# Altium schematic sheet HIGHSPEED_v0_SF2-COMM.SchDoc
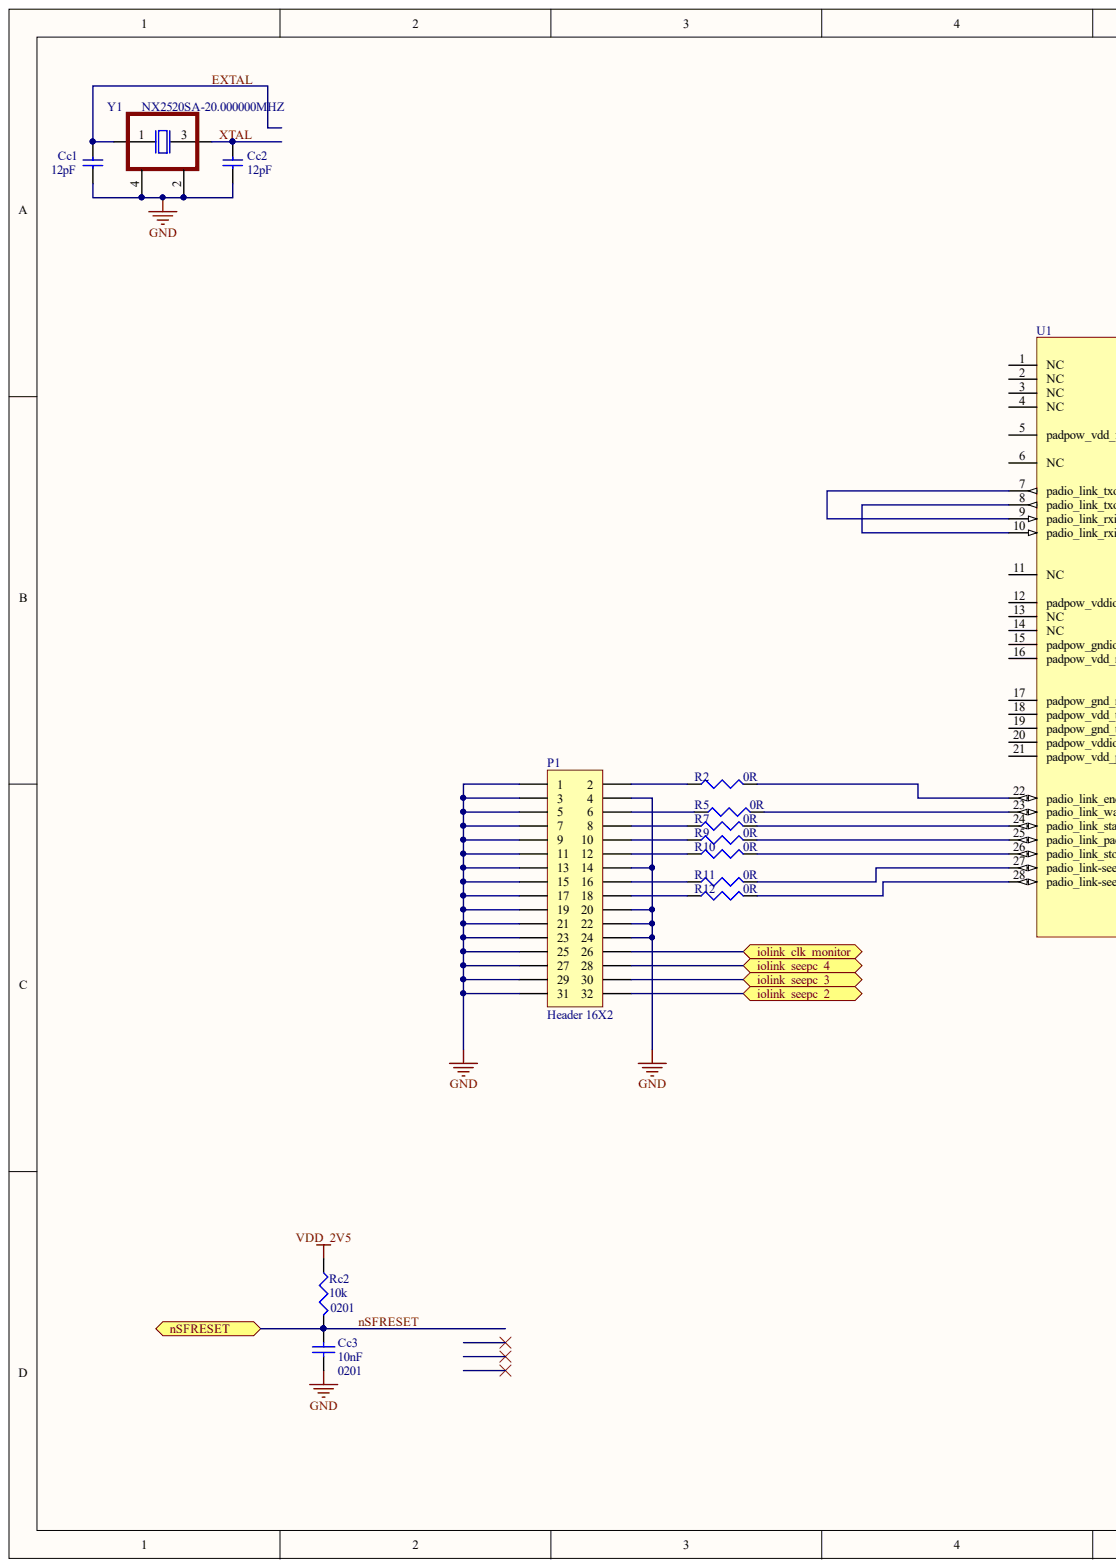
[diagram p0: HIGHSPEED_v0_SF2-COMM.SchDoc, left]
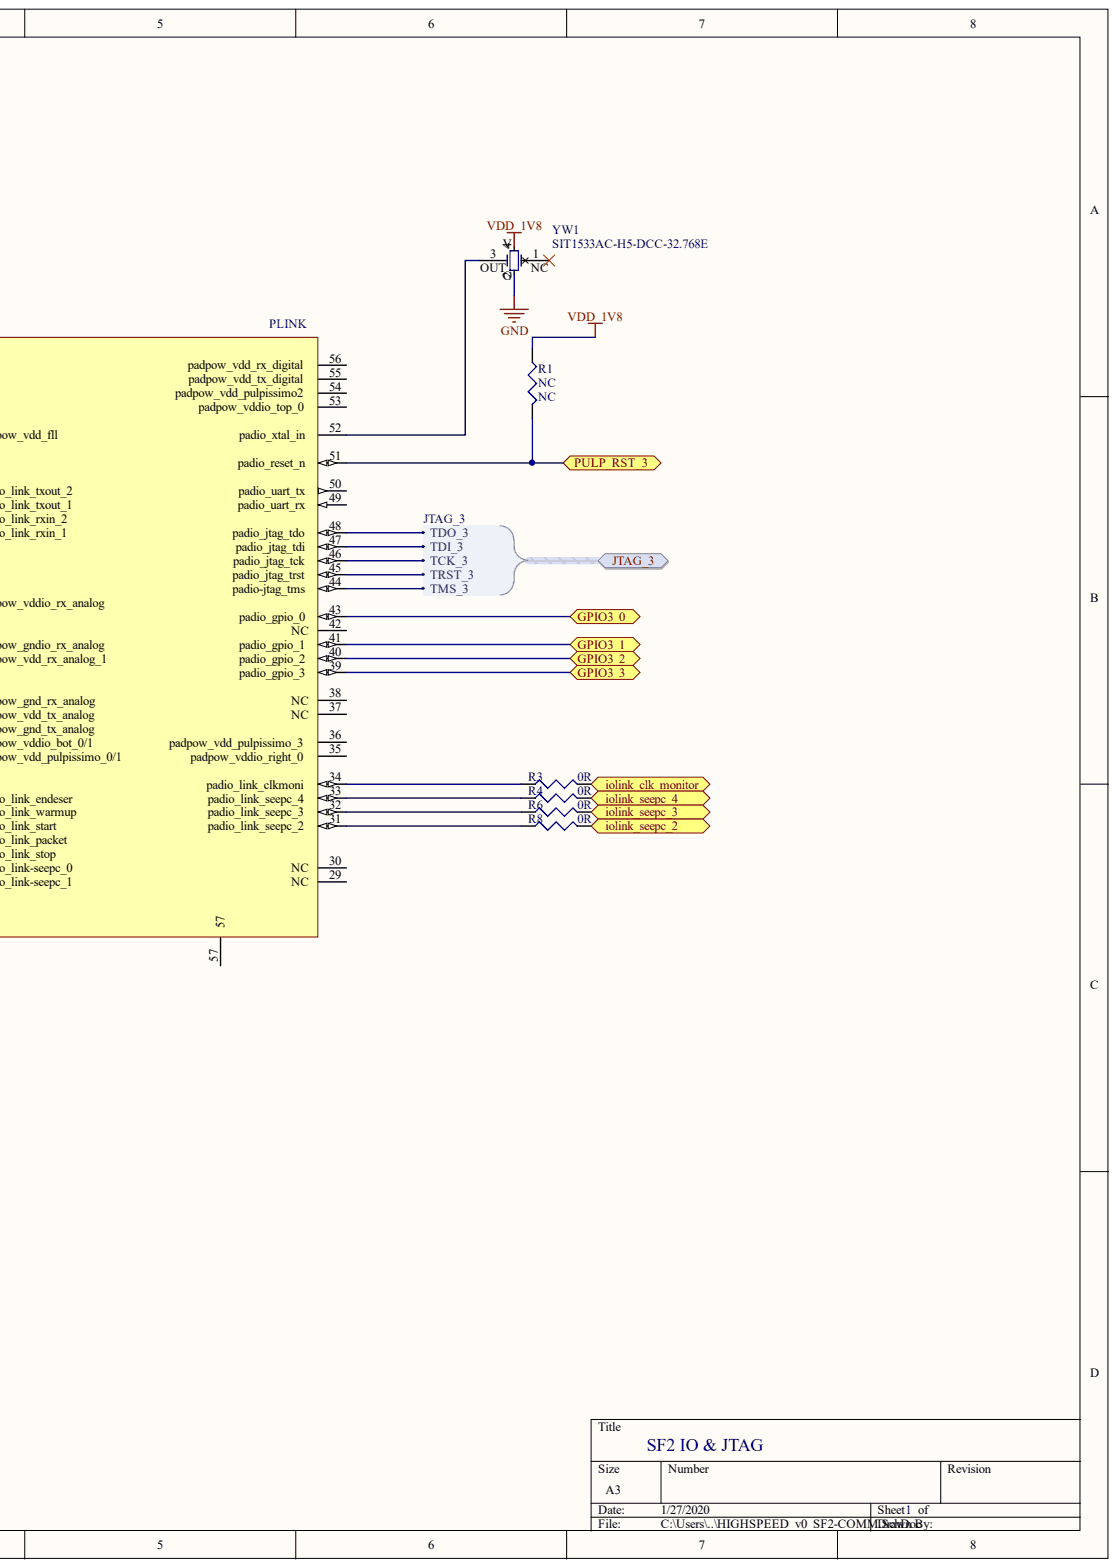
[diagram p1: HIGHSPEED_v0_SF2-COMM.SchDoc, right]

## Parts
Cc1  value 12pF  [c0402-Murata-GR]  (hidden comment: GRM1555C1H120FA01D)
Cc2  value 12pF  [c0402-Murata-GR]  (hidden comment: GRM1555C1H120FA01D)
Cc3  0201  value 10nF  [c0201-Murata-GR]
P1  Header 16X2  [HDR2X16]
R1  NC  [0402]
R2  0R  [0402]
R3  0R  [0402]
R4  0R  [0402]
R5  0R  [0402]
R6  0R  [0402]
R7  0R  [0402]
R8  0R  [0402]
R9  0R  [0402]
R10  0R  [0402]
R11  0R  [0402]
R12  0R  [0402]
Rc2  value 10k  Package: 0201  [0201_p]  (hidden comment: ERJ-1GEF1002C)
U1  PLINK  [QFN56_M]
Y1  NX2520SA-20.000000MHZ  [XOSC_4PIN]
YW1  SIT1533AC-H5-DCC-32.768E  [SiT1533-C]

## Ports
GPIO3_0, GPIO3_1, GPIO3_2, GPIO3_3, IOLINK_CLK_MONITOR, IOLINK_SEEPC_2, IOLINK_SEEPC_3, IOLINK_SEEPC_4, JTAG_3, JTAG_3.TCK_3, JTAG_3.TDI_3, JTAG_3.TDO_3, JTAG_3.TMS_3, JTAG_3.TRST_3, NSFRESET, PULP_RST_3

## Nets
GND: Cc1.1 Cc2.1 Cc3.1 P1.1 P1.3 P1.4 P1.5 P1.7 P1.9 P1.11 P1.13 P1.14 P1.15 P1.17 P1.19 P1.20 P1.21 P1.22 P1.23 P1.24 P1.25 P1.27 P1.29 P1.31 Y1.2 Y1.4 YW1.2
VDD_1V8: R1.2 YW1.4
VDD_2V5: Rc2.1
EXTAL: Cc1.2 Y1.1
GPIO3_0: U1.43(padio_gpio_0) ; port GPIO3_0
GPIO3_1: U1.41(padio_gpio_1) ; port GPIO3_1
GPIO3_2: U1.40(padio_gpio_2) ; port GPIO3_2
GPIO3_3: U1.39(padio_gpio_3) ; port GPIO3_3
NSFRESET: Cc3.2 Rc2.2 ; port NSFRESET
PULP_RST_3: R1.1 U1.51(padio_reset_n) ; port PULP_RST_3
XTAL: Cc2.2 Y1.3
NetP1_2: P1.2 R2.2
NetP1_6: P1.6 R5.2
NetP1_8: P1.8 R7.2
NetP1_10: P1.10 R9.2
NetP1_12: P1.12 R10.2
NetP1_16: P1.16 R11.2
NetP1_18: P1.18 R12.2
NetP1_26: P1.26 R3.1 ; port IOLINK_CLK_MONITOR
NetP1_28: P1.28 R4.1 ; port IOLINK_SEEPC_4
NetP1_30: P1.30 R6.1 ; port IOLINK_SEEPC_3
NetP1_32: P1.32 R8.1 ; port IOLINK_SEEPC_2
NetR2_1: R2.1 U1.22(padio_link_endeser)
NetR3_2: R3.2 U1.34(padio_link_clkmoni)
NetR4_2: R4.2 U1.33(padio_link_seepc_4)
NetR5_1: R5.1 U1.23(padio_link_warmup)
NetR6_2: R6.2 U1.32(padio_link_seepc_3)
NetR7_1: R7.1 U1.24(padio_link_start)
NetR8_2: R8.2 U1.31(padio_link_seepc_2)
NetR9_1: R9.1 U1.25(padio_link_packet)
NetR10_1: R10.1 U1.26(padio_link_stop)
NetR11_1: R11.1 U1.27(padio_link-seepc_0)
NetR12_1: R12.1 U1.28(padio_link-seepc_1)
NetU1_7: U1.7(padio_link_txout_2) U1.9(padio_link_rxin_2)
NetU1_8: U1.8(padio_link_txout_1) U1.10(padio_link_rxin_1)
NetU1_44: U1.44(padio-jtag_tms) ; port JTAG_3
NetU1_45: U1.45(padio_jtag_trst) ; port JTAG_3
NetU1_46: U1.46(padio_jtag_tck) ; port JTAG_3
NetU1_47: U1.47(padio_jtag_tdi) ; port JTAG_3
NetU1_48: U1.48(padio_jtag_tdo) ; port JTAG_3
NetU1_52: U1.52(padio_xtal_in) YW1.3
N00003:
N00004:
N00005:

Not connected: U1 1(NC) 2(NC) 3(NC) 4(NC) 5(padpow_vdd_fll) 6(NC) 11(NC) 12(padpow_vddio_rx_analog) 13(NC) 14(NC) 15(padpow_gndio_rx_analog) 16(padpow_vdd_rx_analog_1) 17(padpow_gnd_rx_analog) 18(padpow_vdd_tx_analog) 19(padpow_gnd_tx_analog) 20(padpow_vddio_bot_0/1) 21(padpow_vdd_pulpissimo_0/1) 29(NC) 30(NC) 35(padpow_vddio_right_0) 36(padpow_vdd_pulpissimo_3) 37(NC) 38(NC) 42(NC) 49(padio_uart_rx) 50(padio_uart_tx) 53(padpow_vddio_top_0) 54(padpow_vdd_pulpissimo2) 55(padpow_vdd_tx_digital) 56(padpow_vdd_rx_digital) 57; YW1 1

## Library data of the parts
Cc1 Cc2 = (no symbol name): CAP CER 12PF 50V NP0 0402; Manufacturer: Murata; Component Kind: Standard; Library Name: Custom.SchLib; Manufacturer PN: GRM1555C1H120FA01D; Supplier: Digikey; Supplier PN: 490-6196-1-ND
Cc3 = Cap: CAP CER 10000PF 25V X5R 0201; Manufacturer: Murata; Manufacturer PN: GRM033R61E103KA12D; Component Kind: Standard; Library Name: Custom.SchLib; Signal Integrity: Cap; Simulation: CAP; Supplier: Digikey; Supplier PN: 490-7194-1-ND
P1 = Header 16X2: Header, 16-Pin, Dual row; Component Kind: Standard; LatestRevisionDate: 17-Jul-2002; LatestRevisionNote: Re-released for DXP Platform.; Library Name: Miscellaneous Connectors.IntLib; Signal Integrity: Connector; Publisher: Altium Limited
R1-R12 = Res3: Resistor; Component Kind: Standard; LatestRevisionDate: 29-May-2009; LatestRevisionNote: IPC-7351 Footprint Added.; Library Name: Miscellaneous Devices.IntLib; PackageDescription: Chip Resistor; PackageReference: J1-0603; Published: 8-Jun-2000; Signal Integrity: Res; Simulation: RESISTOR; Publisher: Altium Limited
  R1: Value: NC
  R2-R12: Value: 0R
Rc2 = Resistor: RES SMD 10K OHM 1% 1/20W 0201; Manufacturer: Panasonic; Component Kind: Standard; Library Name: Custom.SchLib; Manufacturer PN: ERJ-1GEF1002C; Power: 1/20W; Signal Integrity: Res; Simulation: RESISTOR; Supplier: Digikey; Supplier P/N: P10.0KABCT-ND
Y1 = XOSC: CRYSTAL 20.0000MHZ 10PF SMD; Manufacturer: NDK; Component Kind: Standard; Library Name: CM.SchLib; Manufacturer PN: NX2520SA-20.000000MHZ; Supplier: Digikey; Supplier PN: 644-1060-6-ND
YW1 = SIT-OSC32KHZ-SIT1533AC-H5-DCC-32.768: 20 ppm, Ultra-Low Power 32.768 kHz Quartz XTAL Replacement; Current - Supply (max): 1.3uA; Component Kind: Standard; ComponentLink1Description: Datasheet; ComponentLink1URL: http://www.sitime.com/products/datasheets/sit1533/SiT1533-datasheet.pdf; Frequency: 32.768kHz; Supplier 1: Digi-Key; Supplier 2: Digi-Key; Supplier 3: Digi-Key; Supplier 4: Digi-Key; Supplier 5: Digi-Key; Supplier 6: Digi-Key; Supplier 7: Digi-Key; Supplier 8: Digi-Key; Supplier Part Number 1: 1473-1515-2-ND; Supplier Part Number 2: 1473-1515-1-ND; Supplier Part Number 3: 1473-1515-6-ND; Supplier Part Number 4: 1473-1516-2-ND; Supplier Part Number 5: 1473-1516-1-ND; Supplier Part Number 6: 1473-1516-6-ND; Supplier Part Number 7: SIT1533AC-H5-DCC-32.768S-ND; Supplier Part Number 8: SIT1533AC-H5-DCC-32.768D-ND; Library Name: smach_lib.intlib
U1 = PLINK: Component Kind: Standard; Description: PLINK; Library Name: plink.SchLib
